# Altium schematic sheet POWER.SchDoc
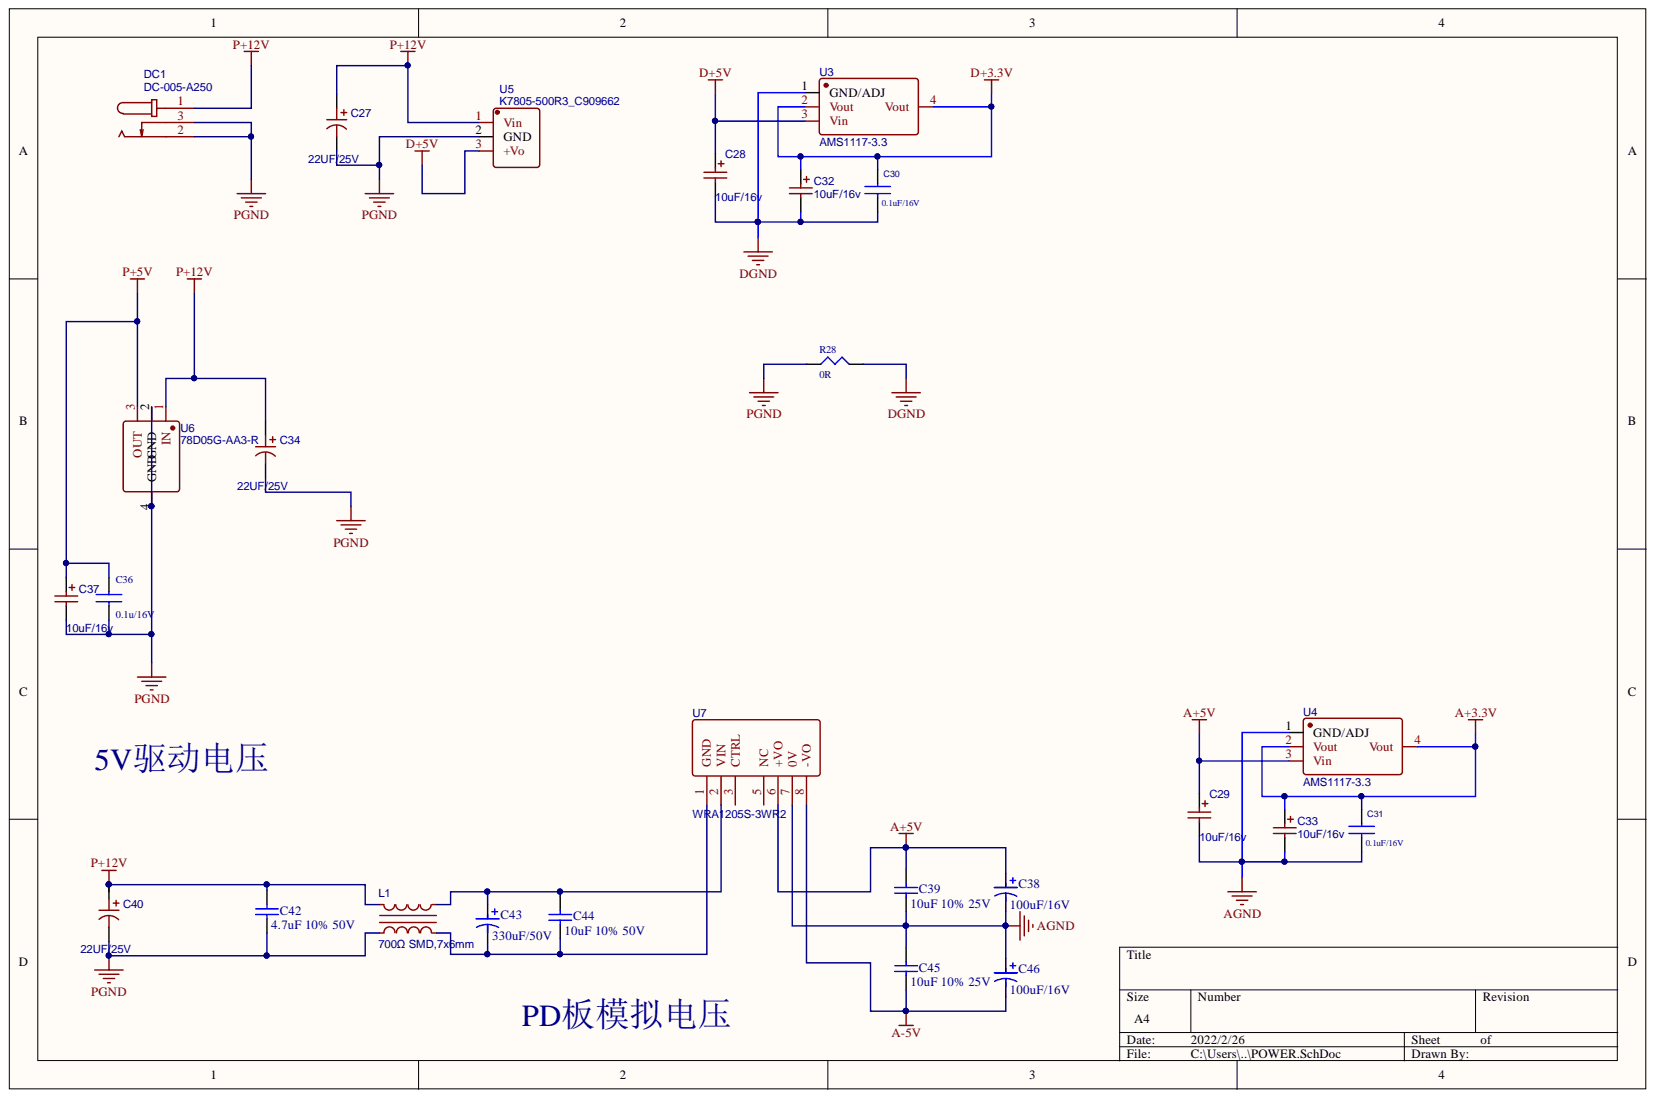
[diagram p0: POWER.SchDoc]

## Parts
C27  22UF/25V  [SMD,5x5.4mm]
C28  10uF/16v  [SMD,5x5.4mm]
C29  10uF/16v  [SMD,5x5.4mm]
C30  0.1uF/16V  [C0603]
C31  0.1uF/16V  [C0603]
C32  10uF/16v  [SMD,5x5.4mm]
C33  10uF/16v  [SMD,5x5.4mm]
C34  22UF/25V  [SMD,5x5.4mm]
C36  0.1u/16V  [C0603]
C37  10uF/16v  [SMD,5x5.4mm]
C38  100uF/16V  [SMD,5x5.4mm]
C39  10uF 10% 25V  [C1206]
C40  22UF/25V  [SMD,5x5.4mm]
C42  4.7uF 10% 50V  [C1206]
C43  330uF/50V  [SMD,10x10.5mm]
C44  10uF 10% 50V  [C1206]
C45  10uF 10% 25V  [C1206]
C46  100uF/16V  [SMD,5x5.4mm]
DC1  DC-005-A250  [DC-IN-TH_DC-005-A250]
L1  700Ω SMD,7x6mm  [SMD,7x6mm]
R28  0R  [R0603]
U3  AMS1117-3.3  [SOT-223]
U4  AMS1117-3.3  [SOT-223]
U5  K7805-500R3_C909662  [K7805-500R3]
U6  78D05G-AA3-R  [SOT-223]
U7  WRA1205S-3WR2  [WRA1205S-3WR2]

## Nets
A+3.3V: C31.1 C33.1 U4.2(Vout) U4.4(Vout)
A+5V: C29.1 C38.1 C39.2 U4.3(Vin) U7.6(+VO)
A-5V: C45.1 C46.2 U7.8(-VO)
AGND: C29.2 C31.2 C33.2 C38.2 C39.1 C45.2 C46.1 U4.1(GND/ADJ) U7.7(0V)
D+3.3V: C30.1 C32.1 U3.2(Vout) U3.4(Vout)
D+5V: C28.1 U3.3(Vin) U5.3
DGND: C28.2 C30.2 C32.2 R28.2 U3.1(GND/ADJ)
P+5V: C36.1 C37.1 U6.3(OUT)
P+12V: C27.1 C34.1 C40.1 C42.2 DC1.1 L1.2 U5.1 U6.1(IN)
PGND: C27.2 C34.2 C36.2 C37.2 C40.2 C42.1 DC1.2 DC1.3 L1.1 R28.1 U5.2 U6.2(GND) U6.4(GND)
NetC43_1: C43.1 C44.2 L1.3 U7.2(VIN)
NetC43_2: C43.2 C44.1 L1.4 U7.1(GND)

Not connected: U7 3(CTRL) 5(NC)

## Library data of the parts
C27 C34 C40 = RVT22UF25V67RV0047: BOM_Manufacturer: KNSCHA(科尼盛); Contributor: LCSC; BOM_JLCPCB Part Class: Extended Part; BOM_Manufacturer Part: RVT22UF25V67RV0047; BOM_Supplier: LCSC; BOM_Supplier Part: C2895283; Component Kind: Standard; spicePre: C; spiceSymbolName: RVT22UF25V67RV0047
C28 C29 C32 C33 C37 = 22uF: BOM_Manufacturer: AVX; BOM_Manufacturer Part: TAJC226K010RNJ; nameAlias: Capacitance; BOM_JLCPCB Part Class: Extended Part; BOM_Supplier: LCSC; BOM_Supplier Part: C127516; Component Kind: Standard; spicePre: C; spiceSymbolName: TAJC226K010RNJ
C30 C31 C36 = CAP NP 0805: Component Kind: Standard
  C36: Description: 0.1u/16V; Library Name: C:\Users\Lenovo\Desktop\定量PCR\电路图\PD板\PD.PcbLib
  C30 C31: Library Name: RAPIDS_ZJB.IntLib
C38 C43 C46 = 100uF 16V: 电解电容; Component Kind: Standard; ComponentLink1Description: 供应商链接; ComponentLink1URL: https://item.szlcsc.com/139751.html; Library Name: Cap.SchLib; Package: SMD,6.3x5.4mm; Supplier: LC; SuppliersPartNumber: C128466
C39 C42 C44 C45 = 0402 10nF (103) 10% 50V: 贴片电容; Component Kind: Standard; ComponentLink1Description: 供应商链接; ComponentLink1URL: http://www.szlcsc.com/product/details_15869.html; Library Name: Cap.SchLib; Package: 0402; Supplier: LC; SuppliersPartNumber: C15195
DC1 = DC-005-A250: BOM_Manufacturer: XUNPU(讯普); Contributor: LCSC; BOM_JLCPCB Part Class: Extended Part; BOM_Manufacturer Part: DC-005-A250; BOM_Supplier: LCSC; BOM_Supplier Part: C720558; Component Kind: Standard; spicePre: D; spiceSymbolName: DC-005-A250
L1 = Sunltech: BOM_Manufacturer: Sunltech Tech; BOM_Manufacturer Part: SMW7060S701NTT; Contributor: LCSC; nameAlias: Value; BOM_Supplier: LCSC; BOM_Supplier Part: C254913; Component Kind: Standard; spicePre: L; spiceSymbolName: SMW7060S701NTT
R28 = RES 0805: Component Kind: Standard; Library Name: C-LifeReal_1.SchLib
U3 U4 = AMS1117-3.3: BOM_Manufacturer: AMS; SMT Type: Basic; sourceId: dwIGgezVh; BOM_JLCPCB SMD: Yes; BOM_Manufacturer Part: AMS1117-3.3; BOM_Supplier: LCSC; BOM_Supplier Part: C6186; Component Kind: Standard; image: //image.lceda.cn/szlcsc/C6186.jpg; spicePre: U; spiceSymbolName: AMS1117-3.3; timeStamp: 1476261164
U5 = K7805-500R3_C909662: BOM_Manufacturer: DEXU Electronics; Contributor: LCSC; BOM_JLCPCB Part Class: Extended Part; BOM_Manufacturer Part: K7805-500R3; BOM_Supplier: LCSC; BOM_Supplier Part: C909662; Component Kind: Standard; spicePre: U; spiceSymbolName: K7805-500R3_C909662
U6 = 78D05G-AA3-R: BOM_Manufacturer: UTC(Unisonic Tech); Contributor: LCSC; BOM_JLCPCB Part Class: Extended Part; BOM_Manufacturer Part: 78D05G-AA3-R; BOM_Supplier: LCSC; BOM_Supplier Part: C484522; Component Kind: Standard; spicePre: U; spiceSymbolName: 78D05G-AA3-R
U7 = WRA1205S-3WR2: BOM_Manufacturer: MORNSUN; BOM_JLCPCB Part Class: Extended Part; BOM_Manufacturer Part: WRA1205S-3WR2; BOM_Supplier: LCSC; BOM_Supplier Part: C124158; Component Kind: Standard; spicePre: U; spiceSymbolName: WRA1205S-3WR2
